# Altium schematic sheet schemat.SchDoc
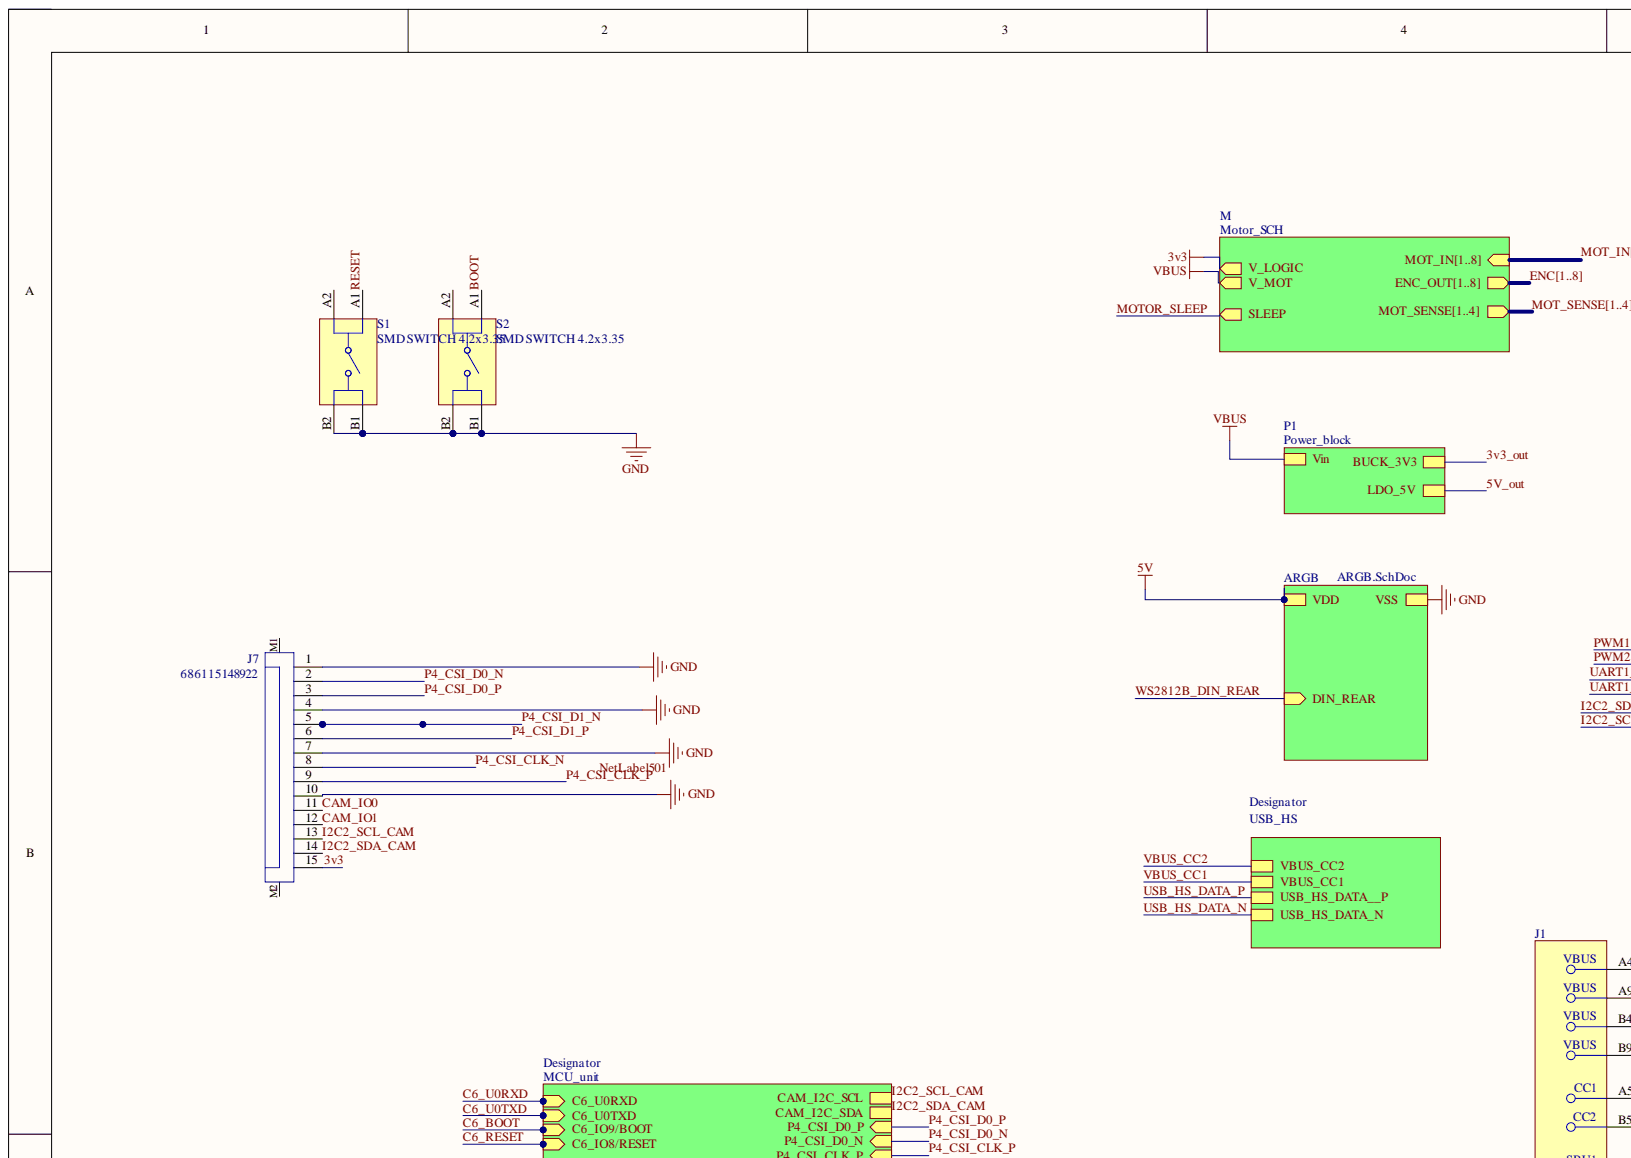
[diagram p0: schemat.SchDoc, top left]
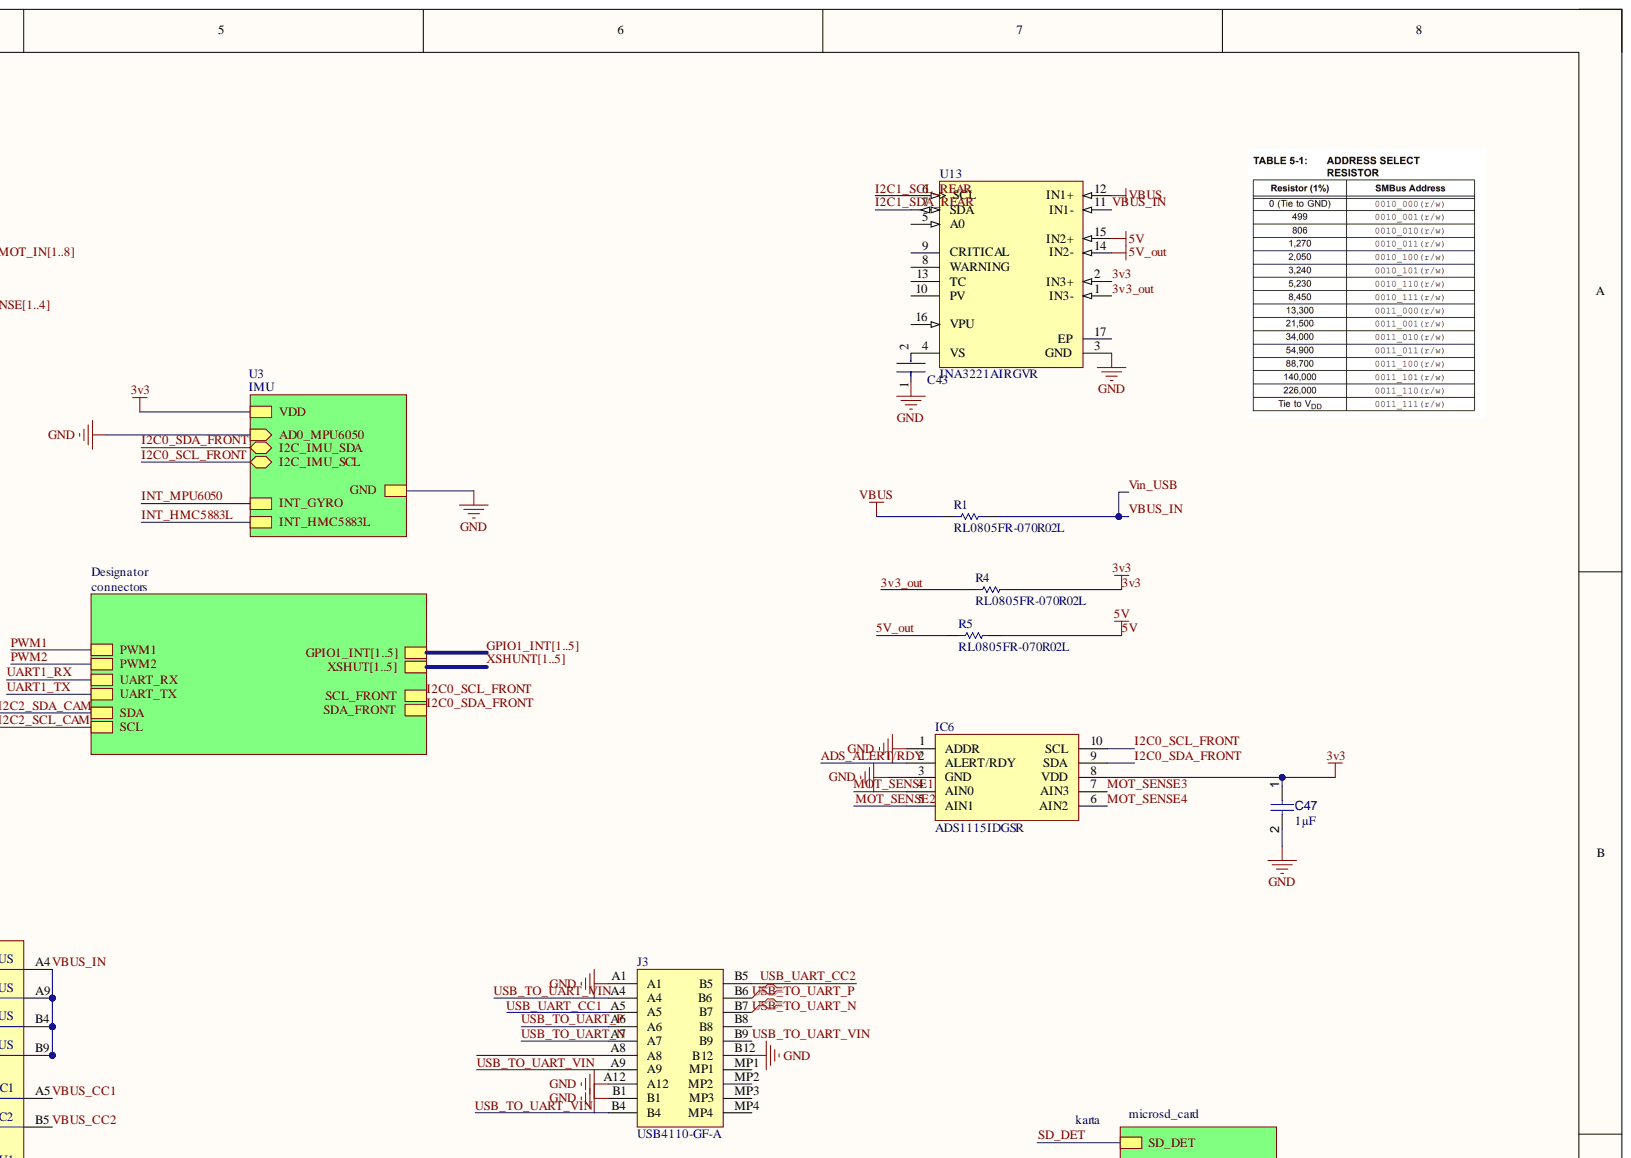
[diagram p1: schemat.SchDoc, top right]
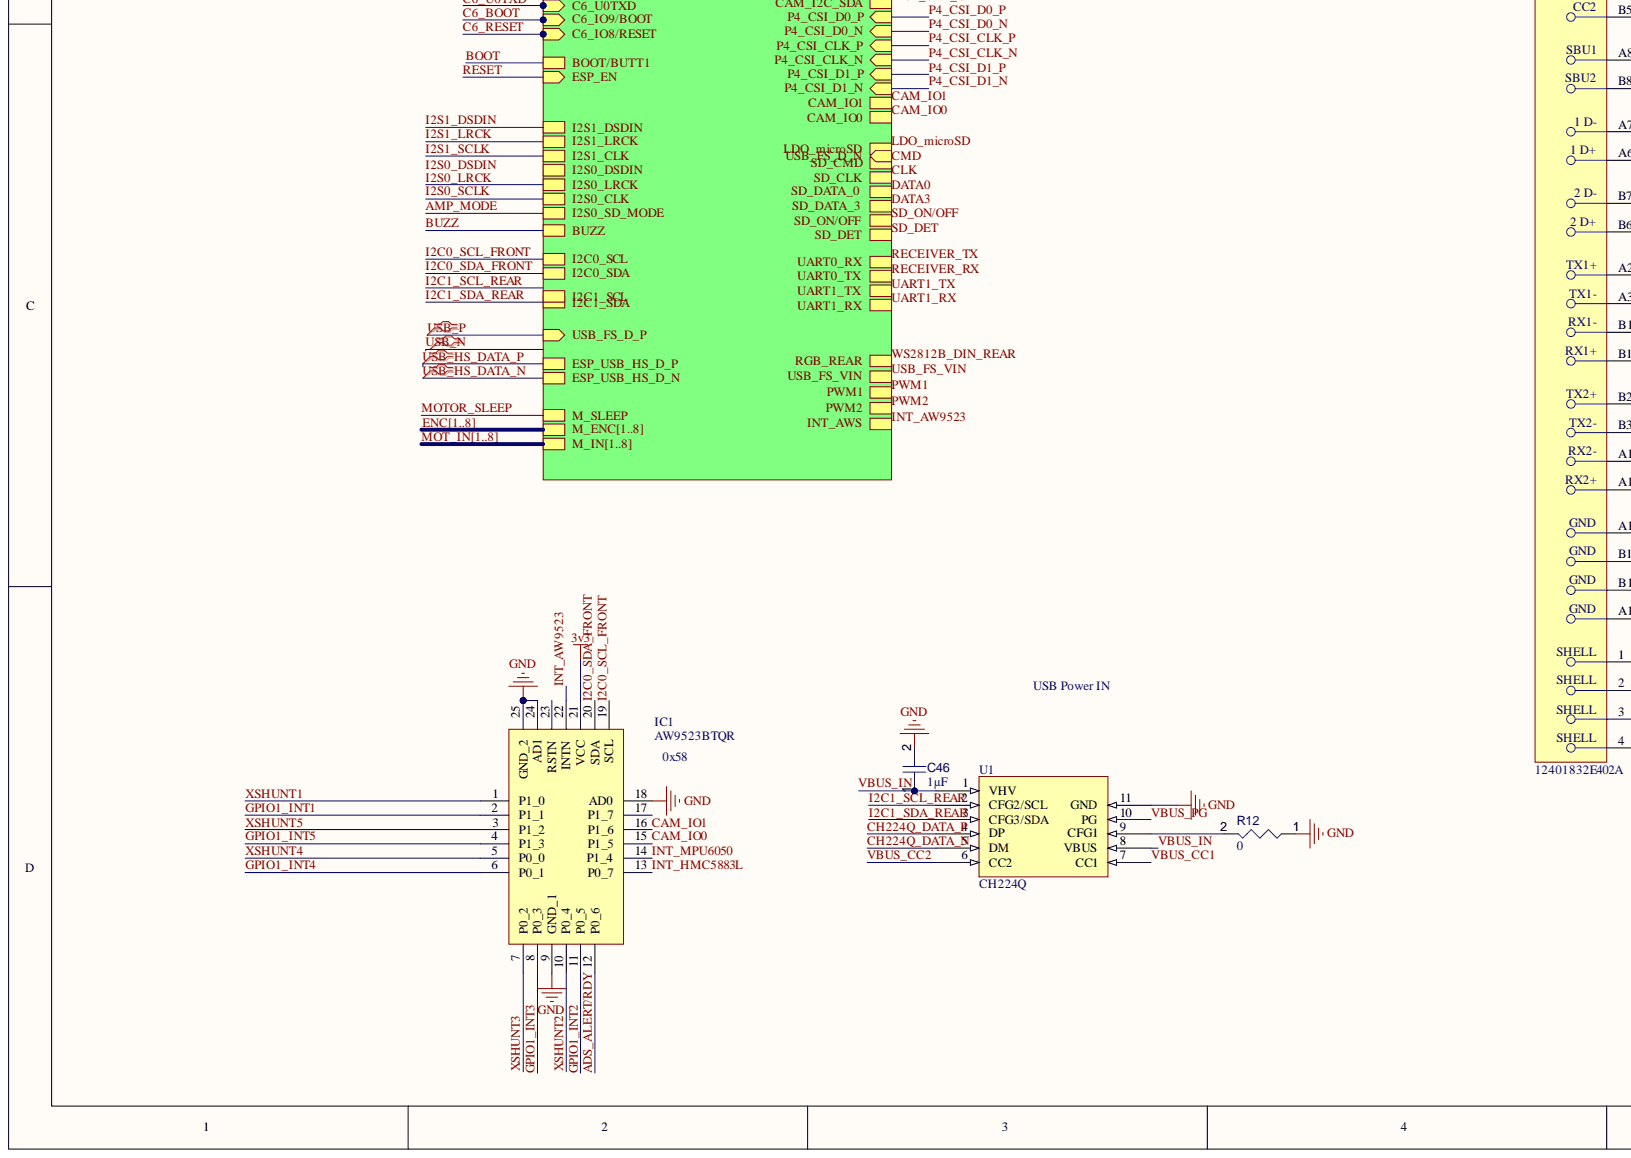
[diagram p2: schemat.SchDoc, bottom left]
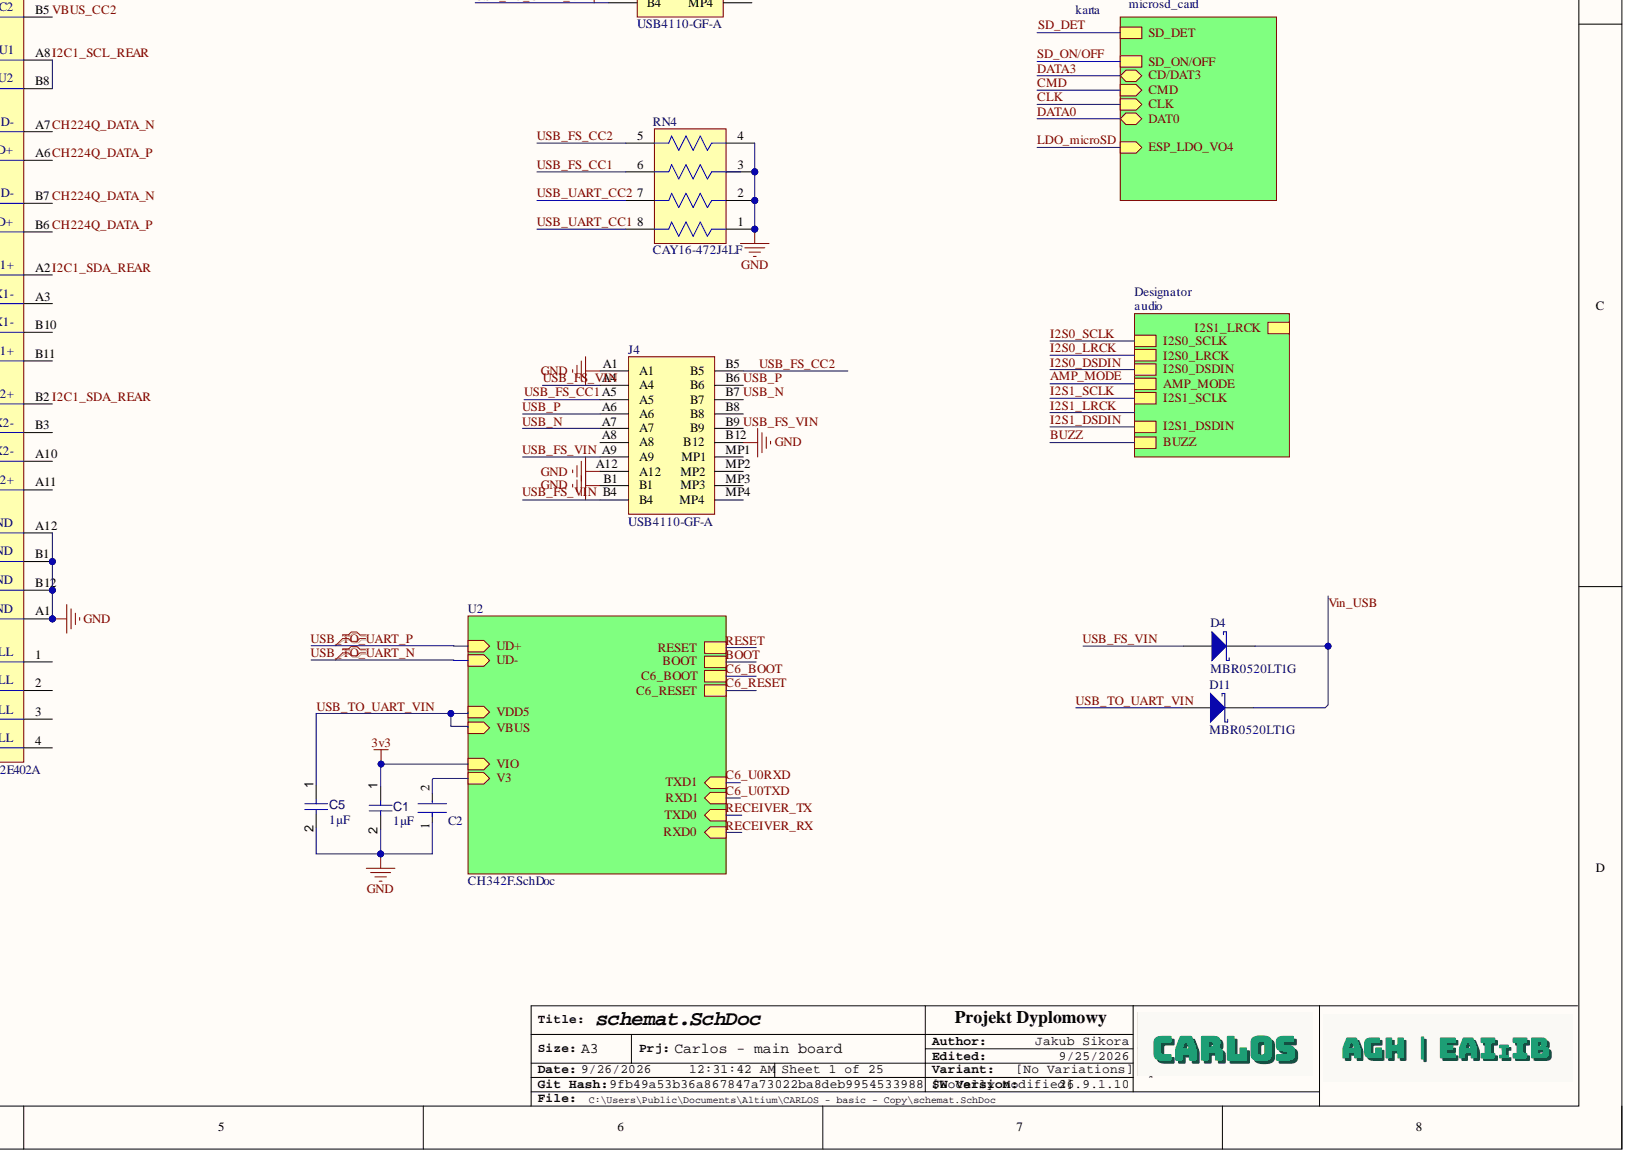
[diagram p3: schemat.SchDoc, bottom right]

## Parts
C1  value 1µF  [CAPC1608X90X40LL10T20]  (hidden comment: Capacitor 1 uF +/- 10% 25 V 0603)
C2  CL10B104KC8NNNC  [FP-CL10-IPC_C]  (value not drawn)
C5  value 1µF  [CAPC1608X90X40LL10T20]  (hidden comment: Capacitor 1 uF +/- 10% 25 V 0603)
C43  CL10B104KC8NNNC  [FP-CL10-IPC_A]  (value not drawn)
C46  value 1µF  [CAPC1608X90X40LL10T20]  (hidden comment: Capacitor 1 uF +/- 10% 25 V 0603)
C47  value 1µF  [CAPC0603(1608)100_L]  (hidden comment: Capacitor 1µF +/-0.1% 25V 0603)
D4  MBR0520LT1G  [ONSC-SOD-123-2-425-04_V]
D11  MBR0520LT1G  [ONSC-SOD-123-2-425-04_V]
IC1  AW9523BTQR  [QFN50P400X400X80-25N-D]
IC6  ADS1115IDGSR  [SOP50P490X110-10N]
J1  12401832E402A  [FP-12401832E402A-MFG]
J3  USB4110-GF-A  [USB4110GFA]
J4  USB4110-GF-A  [USB4110GFA]
J7  686115148922  [686115148922]
R1  RL0805FR-070R02L  [RESC2013X60X35NL10T20]
R4  RL0805FR-070R02L  [RESC2013X60X35NL10T20]
R5  RL0805FR-070R02L  [RESC2013X60X35NL10T20]
R12  value 0  [RESC0603(1608)_L]  (hidden comment: Jumper 0603)
RN4  CAY16-472J4LF  [FP-CAY16-J4-MFG]
S1  SMD SWITCH 4.2x3.35  [SMD SWITCH 4.2x3.35 -Footprint-1]
S2  SMD SWITCH 4.2x3.35  [SMD SWITCH 4.2x3.35 -Footprint-1]
U1  CH224Q  [SON40P200X200X80-11N]
U13  INA3221AIRGVR  [RGV0016A_V]

## Nets
GND: C1.2 C2.1 C5.2 C43.1 C46.2 C47.2 IC1.9(GND_1) IC1.18(AD0) IC1.24(AD1) IC1.25(GND_2) IC6.1(ADDR) IC6.3(GND) J1.A1 J1.A12 J1.B1 J1.B12 J3.A1 J3.A12 J3.B1 J3.B12 J4.A1 J4.A12 J4.B1 J4.B12 J7.1 J7.4 J7.7 J7.10 R12.1 RN4.1 RN4.2 RN4.3 RN4.4 S1.B1 S1.B2 S2.B1 S2.B2 U1.11(GND) U13.3(GND)
VBUS: R1.1 U13.12(IN1+)
3v3: C1.1 C47.1 IC1.21(VCC) IC6.8(VDD) J7.15 R4.2 U13.2(IN3+)
3v3_out: R4.1 U13.1(IN3-)
5V: R5.2 U13.15(IN2+)
5V_out: R5.1 U13.14(IN2-)
ADS_ALERT/RDY: IC1.12(P0_6) IC6.2(ALERT/RDY)
BOOT: S2.A1
CAM_IO0: IC1.15(P1_5) J7.11
CAM_IO1: IC1.16(P1_6) J7.12
CH224Q_DATA_N: J1.A7 J1.B7 U1.5(DM)
CH224Q_DATA_P: J1.A6 J1.B6 U1.4(DP)
GPIO1_INT1: IC1.2(P1_1)  [also labelled GPIO1_INT]
GPIO1_INT2: IC1.11(P0_5)  [also labelled GPIO1_INT]
GPIO1_INT3: IC1.8(P0_3)  [also labelled GPIO1_INT]
GPIO1_INT4: IC1.6(P0_1)  [also labelled GPIO1_INT]
GPIO1_INT5: IC1.4(P1_3)  [also labelled GPIO1_INT]
I2C0_SCL_FRONT: IC1.19(SCL) IC6.10(SCL)
I2C0_SDA_FRONT: IC1.20(SDA) IC6.9(SDA)
I2C1_SCL_REAR: J1.A8 J1.B8 U1.2(CFG2/SCL) U13.6(SCL)
I2C1_SDA_REAR: J1.A2 J1.B2 U1.3(CFG3/SDA) U13.7(SDA)
I2C2_SCL_CAM: J7.13
I2C2_SDA_CAM: J7.14
INT_AW9523: IC1.22(INTN)
INT_HMC5883L: IC1.13(P0_7)
INT_MPU6050: IC1.14(P1_4)
MOT_SENSE1: IC6.4(AIN0)  [also labelled MOT_SENSE]
MOT_SENSE2: IC6.5(AIN1)  [also labelled MOT_SENSE]
MOT_SENSE3: IC6.7(AIN3)  [also labelled MOT_SENSE]
MOT_SENSE4: IC6.6(AIN2)  [also labelled MOT_SENSE]
P4_CSI_CLK_N: J7.8
P4_CSI_CLK_P: J7.9
P4_CSI_D0_N: J7.2
P4_CSI_D0_P: J7.3
P4_CSI_D1_N: J7.5
P4_CSI_D1_P: J7.6
RESET: S1.A1
USB_FS_CC1: J4.A5 RN4.6
USB_FS_CC2: J4.B5 RN4.5
USB_FS_VIN: D4.1 J4.A4 J4.A9 J4.B4 J4.B9
USB_N: J4.A7 J4.B7
USB_P: J4.A6 J4.B6
USB_TO_UART_N: J3.A7 J3.B7
USB_TO_UART_P: J3.A6 J3.B6
USB_TO_UART_VIN: C5.1 D11.1 J3.A4 J3.A9 J3.B4 J3.B9
USB_UART_CC1: J3.A5 RN4.8
USB_UART_CC2: J3.B5 RN4.7
VBUS_CC1: J1.A5 U1.7(CC1)
VBUS_CC2: J1.B5 U1.6(CC2)
VBUS_IN: C46.1 D4.2 D11.2 J1.A4 J1.A9 J1.B4 J1.B9 R1.2 U1.1(VHV) U1.8(VBUS) U13.11(IN1-)
VBUS_PG: U1.10(PG)
XSHUNT1: IC1.1(P1_0)  [also labelled XSHUNT]
XSHUNT2: IC1.10(P0_4)  [also labelled XSHUNT]
XSHUNT3: IC1.7(P0_2)  [also labelled XSHUNT]
XSHUNT4: IC1.5(P0_0)  [also labelled XSHUNT]
XSHUNT5: IC1.3(P1_2)  [also labelled XSHUNT]
NetC43_2: C43.2 U13.4(VS)
NetR12_2: R12.2 U1.9(CFG1)
AMP_MODE:
BUZZ:
C6_BOOT:
C6_RESET:
C6_U0RXD:
C6_U0TXD:
CLK:
CMD:
DATA0:
DATA3:
ENC1:  [also labelled ENC]
ENC2:  [also labelled ENC]
ENC3:  [also labelled ENC]
ENC4:  [also labelled ENC]
ENC5:  [also labelled ENC]
ENC6:  [also labelled ENC]
ENC7:  [also labelled ENC]
ENC8:  [also labelled ENC]
I2C1_SCL:
I2S0_DSDIN:
I2S0_LRCK:
I2S0_SCLK:
I2S1_DSDIN:
I2S1_LRCK:
I2S1_SCLK:
LDO_microSD:
MOT_IN1:  [also labelled MOT_IN]
MOT_IN2:  [also labelled MOT_IN]
MOT_IN3:  [also labelled MOT_IN]
MOT_IN4:  [also labelled MOT_IN]
MOT_IN5:  [also labelled MOT_IN]
MOT_IN6:  [also labelled MOT_IN]
MOT_IN7:  [also labelled MOT_IN]
MOT_IN8:  [also labelled MOT_IN]
MOTOR_SLEEP:
NetLabel501:
PWM1:
PWM2:
RECEIVER_RX:
RECEIVER_TX:
SD_DET:
SD_ON/OFF:
UART1_RX:
UART1_TX:
USB_FS_D_N:
USB_HS_DATA_N:
USB_HS_DATA_P:
WS2812B_DIN_REAR:

Not connected: C2 2; IC1 17(P1_7) 23(RSTN); J1 1 2 3 4 A3 A10 A11 B3 B10 B11; J3 A8 B8 MP1 MP2 MP3 MP4; J4 A8 B8 MP1 MP2 MP3 MP4; J7 M1 M2; S1 A2; S2 A2; U13 5(A0) 8(WARNING) 9(CRITICAL) 10(PV) 13(TC) 16(VPU) 17(EP)

## Library data of the parts
C1 C5 C46 = CMP-001-00005-6: Chip Capacitor, 1 uF, +/- 10%, 25 V, 0603 (1608 Metric); Capacitor Type: Ceramic; Voltage Rating: 25V; Case/Package: 0603; Component Kind: Standard; Max Operating Temperature: 125°C; Min Operating Temperature: -55°C; Mounting Technology: SM; Pins: 2; RoHS Compliant: True; Tolerance: 10%; Library Name: Jakub Sikora
C2 C43 = CMP-13271-004036-1: Cap Ceramic 100nF 100V X7R ±10% Pad SMD 0603 +125°C T/R; Capacitance: 100nF; Case Code (Imperial): 0603; Case Code (Metric): 1608; Case/Package: 0603; Component Kind: Standard; Length: 0.063inch; Library Name: Altium Content Vault; Max Operating Temperature: 125°C; Min Operating Temperature: -55°C; Mount: Surface Mount; Packaging: Cut Tape; Thickness: 0.035inch; Tolerance: 10%; Voltage Rating: 100V; Width: 0.031inch
C47 = CMP-001-00055-4: Chip Capacitor, 1µF +/-0.1%, 25V, 0603, Thickness 1 mm; Tolerance: 20%; Case/Package: 0603; Component Kind: Standard; Max Operating Temperature: 85°C; Min Operating Temperature: -55°C; Mounting Technology: SMT; Pins: 2; Voltage Rating: 25V; Library Name: Jakub Sikora
D4 D11 = CMP-004-00003-2: Schottky Power Rectifier, 20 V, 5.5 A, -65 to 125 degC, 2-Pin SMD, RoHS, Tape and Reel; Manufacturer: ON Semiconductor; Elements: 1; Forward Current: 500mA; Forward Voltage: 385mV; Max Surge Current: 5.5A; Peak Reverse Current: 250uA; Case/Package: SOD; Component Kind: Standard; Datasheet URL: http://www.onsemi.cn/pub_link/Collateral/MBR0520LT1-D.PDF; Datasheet Version: Rev. 6, 01/2012; Manufacturer URL: http://www.onsemi.com/; Max Operating Temperature: 125°C; Min Operating Temperature: -65°C; Mounting Technology: SM; Package Description: 2-Pin Surface Mount Device, Body 2.69 x 1.6 mm; Package Quantity: 3000; Package Reference: SOD-123-2-425-04; Packaging: TapeandReel; Pins: 2; RoHS Compliant: True; Library Name: Jakub Sikora
IC1 = AW9523BTQR: Integrated Circuit; Manufacturer_Name: AWINIC; Component Kind: Standard; Datasheet Link: https://www.alldatasheet.net/datasheet-pdf/pdf/1148543/AWINIC/AW9523BTQR.html; Height: 0.8mm; Library Name: SamacSys.SchLib; Manufacturer_Part_Number: AW9523BTQR
IC6 = CMP-031-00056-1: Integrated Circuit; Manufacturer_Name: Texas Instruments; Arrow Part Number: ADS1115IDGSR; Arrow Price/Stock: https://www.arrow.com/en/products/ads1115idgsr/texas-instruments?utm_currency=USD&region=nac; Component Kind: Standard; Datasheet Link: http://www.ti.com/lit/gpn/ADS1115; Design Item ID: ADS1115IDGSR; Height: 1.1mm; Manufacturer_Part_Number: ADS1115IDGSR; Mouser Part Number: 595-ADS1115IDGSR; Mouser Price/Stock: https://www.mouser.co.uk/ProductDetail/Texas-Instruments/ADS1115IDGSR?qs=IK5e5L0zOXjKCJoVMhYO%2FQ%3D%3D; Source: SamacSys.SchLib; Library Name: Jakub Sikora
J1 = CMP-02355-000004-1: CONN RCP USB3.1 TYPEC 24P SMD RA; Component Kind: Standard; Library Name: Altium Content Vault
J3 J4 = CMP-002-00092-1: Connector; Manufacturer_Name: GCT (GLOBAL CONNECTOR TECHNOLOGY); Component Kind: Standard; Datasheet Link: https://gct.co/files/drawings/usb4110.pdf; Design Item ID: USB4110-GF-A; Height: 3.26mm; Manufacturer_Part_Number: USB4110-GF-A; Mouser Part Number: 640-USB4110-GF-A; Mouser Price/Stock: https://www.mouser.co.uk/ProductDetail/GCT/USB4110-GF-A?qs=KUoIvG%2F9IlYiZvIXQjyJeA%3D%3D; Source: SamacSys.SchLib; Library Name: Jakub Sikora
J7 = CMP-1502-01518-3: Wr-fpc; 1MM Pitch 15 Way Horizontal SMT Female Fpc Connector, Zif Bottom Contact; Actuator Material: Nylon, Polyamide; Contact Resistance: 50mΩ; Current Rating: 1A; Gender: Receptacle; Insulation Resistance: 500MΩ; Number of Contacts: 15; Operating Supply Voltage: 100V; Wire/Cable Type: Straight; Component Kind: Standard; Contact Material: Bronze; Contact Plating: Tin; Flammability Rating: UL94 V-0; Lead Pitch: 1mm; Length: 16.1mm; Library Name: Altium Content Vault; Max Operating Temperature: 105°C; Min Operating Temperature: -40°C; Mount: Surface Mount; Number of Pins: 15; Number of Positions: 15; Number of Rows: 1; Orientation: Horizontal; Packaging: Tape and Reel; Pitch: 1mm; REACH SVHC: Unknown; RoHS: Compliant; Termination: Solder; Voltage Rating: 100V
R1 R4 R5 = CMP-2001-01438-1: Manufacturer: Yageo; Component Kind: Standard; Library Name: Altium Content Vault; Part Number: RL0805FR-070R02L
R12 = CMP-009-00175-3: Case/Package: 0603; Component Kind: Standard; Description: Jumper 0603; Max Operating Temperature: 155°C; Min Operating Temperature: -55°C; Pins: 2; Library Name: Jakub Sikora
RN4 = CMP-07230-000006-1: zamiast tego 4D03WGJ0512T5E; Circuit Type: Isolated; Number of Circuits: 4; Number of Terminals/Pins: 8; Power Per Element: 62.5mW; Power Rating: 248mW; Resistance: 4.7kR; Resistance Tolerance: 5%; Temperature Coefficient: 200ppm/°C; Voltage Rating: 50V; Case Code (Imperial): 1206; Case Code (Metric): 3216; Case/Package: 1206; Component Kind: Standard; Contact Plating: Tin; Depth: 1.6mm; Height: 0.024inch; Length: 3.2mm; Library Name: Altium Content Vault; Max Operating Temperature: 125°C; Military Standard: Not; Min Operating Temperature: -55°C; Mount: Surface Mount; Number of Elements: 4; Number of Pins: 8; Number of Resistors: 4; Package Quantity: 1; Packaging: Tape and Reel; Radiation Hardening: No; REACH SVHC: No SVHC; Resistor Type: Thick Film; Series: CAY16; Technology: THICK FILM; Terminal Pitch: 0.8mm; Termination: Convex; Tolerance: 5%; Voltage Rating (DC): 50V; Width: 0.063inch
S1 S2 = CMP-011-00013-2: 4P smd switch; Component Kind: Standard; Library Name: Jakub Sikora
U1 = CMP-018-000006-1: Component Kind: Standard; Design Item ID: CH224Q; Source: SamacSys.SchLib; Library Name: Jakub Sikora
U13 = CMP-0704-00001-2: Triple Channel Shunt and Bus Voltage Monitor, 120 dB, 2.7 to 5.5 V, -40 to 125 degC, 16-Pin VQFN (RGV), Green (RoHS & no Sb/Br), Tape and Reel; Manufacturer: Texas Instruments; CMR (Typ): 120 dB; Gain: 1 V/V; Gain Error (max): 0.25%; Offset Voltage (max): +/- 80 uV; Quiescent Current (Typ): 350 uA; Rating: Catalog; Voltage Input Range (Bus): 0 to 26 V; Component Kind: Standard; ComponentLink1Description: Manufacturer URL; ComponentLink1URL: http://www.ti.com/; ComponentLink2Description: Datasheet; ComponentLink2URL: http://www.ti.com/lit/ds/symlink/ina3221.pdf; ComponentLink3Description: Package Specification; ComponentLink3URL: http://www.ti.com/lit/ds/symlink/ina3221.pdf; DatasheetVersion: SBOS576A; Library Name: Altium Content Vault; Mounting Technology: Surface Mount; Operating Supply Range: 2.7 to 5.5 V; PackageDescription: 16-Pin Quad Flat No-lead Package, Body 4 x 4 mm, Pitch 0.65 mm; PackageReference: RGV0016A; PackageVersion: SBOS576A; Packing: Tape and Reel; PartNumber: INA3221AIRGVR; RoHS: TRUE; Temperature Range: -40 to 125 degC
